# Altium schematic sheet nanoDLA.SchDoc
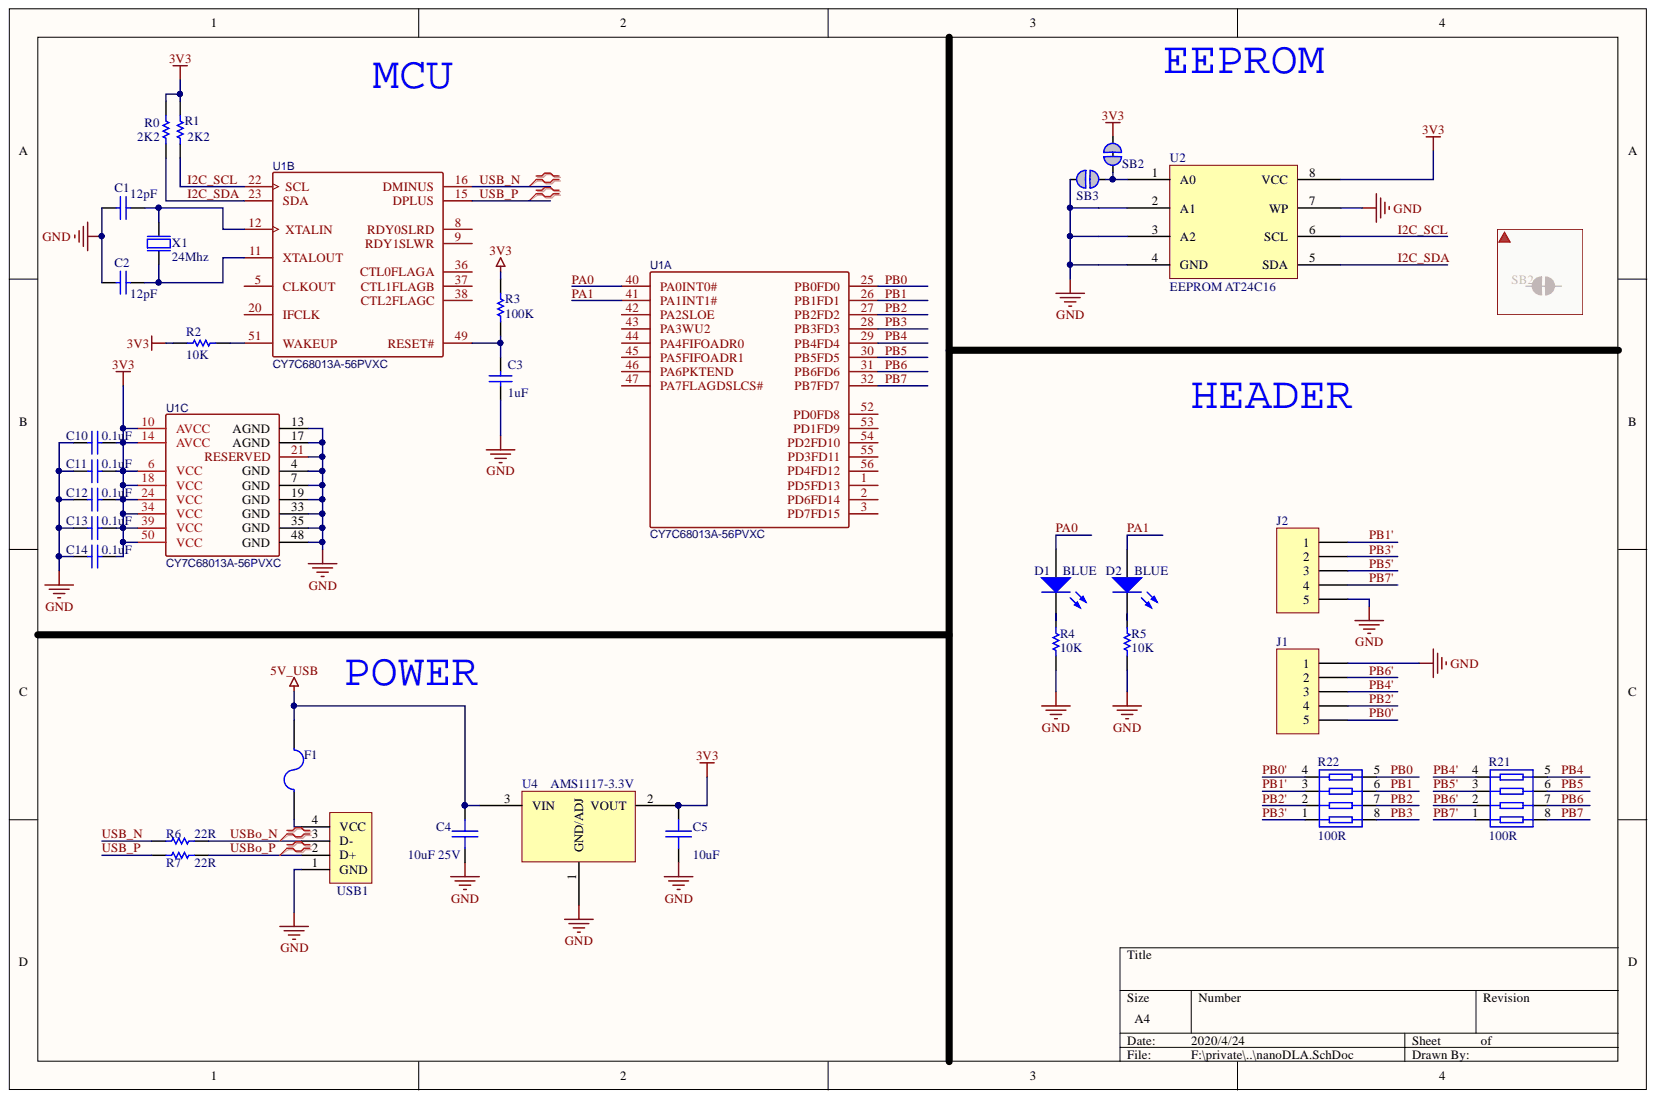
[diagram p0: nanoDLA.SchDoc]

## Parts
C1  value 12pF  [0603_C]  (hidden comment: C0603)
C2  value 12pF  [0603_C]  (hidden comment: C0603)
C3  value 1uF  [0603_C]  (hidden comment: C0603)
C4  10uF 25V  [0603_C]
C5  10uF  [0603_C]
C10  value 0.1uF  [0603_C]  (hidden comment: C0603)
C11  value 0.1uF  [0603_C]  (hidden comment: C0603)
C12  value 0.1uF  [0603_C]  (hidden comment: C0603)
C13  value 0.1uF  [0603_C]  (hidden comment: C0603)
C14  value 0.1uF  [0603_C]  (hidden comment: C0603)
D1  BLUE  [0603_LED]
D2  BLUE  [0603_LED]
F1  Fuse 2  [FU 0805]  (value not drawn)
J1  Header 5  [HEADER_SMT_5]  (value not drawn)
J2  Header 5  [HEADER_SMT_5]  (value not drawn)
R0  value 2K2  [0603_R]  (hidden comment: R0603)
R1  value 2K2  [0603_R]  (hidden comment: R0603)
R2  value 10K  [0603_R]  (hidden comment: R0603)
R3  value 100K  [0603_R]  (hidden comment: R0603)
R4  value 10K  [0603_R]  (hidden comment: R0603)
R5  value 10K  [0603_R]  (hidden comment: R0603)
R6  value 22R  [0603_R]  (hidden comment: R0603)
R7  value 22R  [0603_R]  (hidden comment: R0603)
R21  100R  [RCA-8P4R-0603]
R22  100R  [RCA-8P4R-0603]
SB2  Solderbridge  [Solderbridge_Shorted]  (value not drawn)
SB3  Solderbridge  [Solderbridge]  (value not drawn)  (no BOM)
U1  CY7C68013A-56PVXC  [SSOP-56_L18.5-W7.6-P0.63-LS10.7-BR]  (parts A,B,C)
U2  EEPROM AT24C16  [SOP8_M]
U4  AMS1117-3.3V  [SOT-89]
USB1  USB  [USB_A/P_B]
X1  24Mhz  [BT-XTAL_3225]

## Nets
3V3: C5.1 C10.1 C11.1 C12.1 C13.1 C14.1 R0.2 R1.2 R2.2 R3.1 SB2.1 U1.6(VCC) U1.10(AVCC) U1.14(AVCC) U1.18(VCC) U1.24(VCC) U1.34(VCC) U1.39(VCC) U1.50(VCC) U2.8(VCC) U4.2
5V_USB: C4.1 F1.2 U4.3
GND: C1.2 C2.2 C3.1 C4.2 C5.2 C10.2 C11.2 C12.2 C13.2 C14.2 J1.1 J2.5 R4.1 R5.1 SB3.1 U1.4(GND) U1.7(GND) U1.13(AGND) U1.17(AGND) U1.19(GND) U1.21(RESERVED) U1.33(GND) U1.35(GND) U1.48(GND) U2.2(A1) U2.3(A2) U2.4(GND) U2.7(WP) U4.1 USB1.1(GND) X1.2 X1.4
I2C_SCL: R1.1 U1.22(SCL) U2.6(SCL)
I2C_SDA: R0.1 U1.23(SDA) U2.5(SDA)
PA0: D1.1 U1.40(PA0INT0#)
PA1: D2.1 U1.41(PA1INT1#)
PB0: R22.5 U1.25(PB0FD0)
PB0': J1.5 R22.4
PB1: R22.6 U1.26(PB1FD1)
PB1': J2.1 R22.3
PB2: R22.7 U1.27(PB2FD2)
PB2': J1.4 R22.2
PB3: R22.8 U1.28(PB3FD3)
PB3': J2.2 R22.1
PB4: R21.5 U1.29(PB4FD4)
PB4': J1.3 R21.4
PB5: R21.6 U1.30(PB5FD5)
PB5': J2.3 R21.3
PB6: R21.7 U1.31(PB6FD6)
PB6': J1.2 R21.2
PB7: R21.8 U1.32(PB7FD7)
PB7': J2.4 R21.1
USB_N: R6.1 U1.16(DMINUS)
USB_P: R7.1 U1.15(DPLUS)
USBo_N: R6.2 USB1.3(D-)
USBo_P: R7.2 USB1.2(D+)
NetC1_1: C1.1 U1.12(XTALIN) X1.1
NetC2_1: C2.1 U1.11(XTALOUT) X1.3
NetC3_2: C3.2 R3.2 U1.49(RESET#)
NetD1_2: D1.2 R4.2
NetD2_2: D2.2 R5.2
NetF1_1: F1.1 USB1.4(VCC)
NetR2_1: R2.1 U1.51(WAKEUP)
NetSB2_2: SB2.2 SB3.2 U2.1(A0)

Not connected: U1 1(PD5FD13) 2(PD6FD14) 3(PD7FD15) 5(CLKOUT) 8(RDY0SLRD) 9(RDY1SLWR) 20(IFCLK) 36(CTL0FLAGA) 37(CTL1FLAGB) 38(CTL2FLAGC) 42(PA2SLOE) 43(PA3WU2) 44(PA4FIFOADR0) 45(PA5FIFOADR1) 46(PA6PKTEND) 47(PA7FLAGDSLCS#) 52(PD0FD8) 53(PD1FD9) 54(PD2FD10) 55(PD3FD11) 56(PD4FD12)

## Library data of the parts
C1-C3 C10-C14 = C0603: Capacitor; Component Kind: Standard; LatestRevisionDate: 17-Jul-2002; LatestRevisionNote: Re-released for DXP Platform.; Library Name: MYLIB.IntLib; PackageDescription: Radial Cap, Thru-Hole; 2 Leads; 0.3 in Pin Spacing; PackageReference: RAD-0.3; Published: 8-Jun-2000; Signal Integrity: Cap; Simulation: CAP; Publisher: Altium Limited
C4 = SMT_C_0805: Surface mount capacitor 0805; Component Kind: Standard; Library Name: Vowstar_Basic_Device.IntLib
C5 = SMT_C_0402: Surface mount capacitor 0402; Component Kind: Standard; Library Name: Vowstar_Basic_Device.IntLib
D1 D2 = D0603: Typical RED, GREEN, YELLOW, AMBER GaAs LED; Component Kind: Standard; LatestRevisionDate: 17-Jul-2002; LatestRevisionNote: Re-released for DXP Platform.; Library Name: MYLIB.IntLib; PackageDescription: SM LED; 2 Flat Leads; PackageReference: 3.2X1.6X1.1; PackageVersion: Feb-2002; Published: 8-Jun-2000; Simulation: LED2; Publisher: Altium Limited
F1 = Fuse 2: Fuse; Component Kind: Standard; LatestRevisionDate: 17-Jul-2002; LatestRevisionNote: Re-released for DXP Platform.; Library Name: Miscellaneous Devices.IntLib; PackageDescription: Thru-Hole; 2 Leads; PackageReference: PIN-W2/E2.8; Published: 8-Jun-2000; Simulation: FUSE; Publisher: Altium Limited
J1 J2 = Header_5: Header, 4-Pin; Component Kind: Standard; LatestRevisionDate: 17-Jul-2002; LatestRevisionNote: Re-released for DXP Platform.; Signal Integrity: Connector; Library Name: nanoDAP.SCHLIB; Publisher: Altium Limited
R0-R7 = R0603: Resistor; Component Kind: Standard; LatestRevisionDate: 17-Jul-2002; LatestRevisionNote: Re-released for DXP Platform.; Library Name: MYLIB.IntLib; PackageDescription: Axial Device, Thru-Hole; 2 Leads; 0.3 in Pin Spacing; PackageReference: AXIAL-0.3; Published: 8-Jun-2000; Signal Integrity: Res; Simulation: RESISTOR; Publisher: Altium Limited
R21 R22 = 排阻8PIN: Component Kind: Standard; Library Name: nanoDLA.SCHLIB
SB2 = Solderbridge Shorted: Shorted Solderbridge; Artikkelnr Noca: N.A.; Database Table Name: Connectors$; Artikkelnr Simpro: N.A.; Component Kind: Net Tie; Footprint Doc: Solderbridge_Shorted; LatestRevisionDate: 2012-08-07; Library Name: Nordic Passive DB.DbLib; Part Number: Solderbridge Shorted; Pin Count: 2; Published: 2012-08-07; Value: Solderbridge; Publisher: Nordic Semiconductor
SB3 = (no symbol name): Artikkelnr Noca: N.A.; Artikkelnr Simpro: N.A.; Description: Solderbridge; Footprint Doc: Solderbridge; LatestRevisionDate: 2009-12-14; Library Name: NODEMCU_ESP12.SCHLIB; Part Number: Solderbridge; Pin Count: 2; Published: 2009-12-14; Value: Solderbridge; Publisher: Nordic Semiconductor
U1 = CY7C68013A-56PVXC: BOM_Manufacturer: CYPRESS; subpart_no: 1; tags: PSOC1-M8C; BOM_Manufacturer Part: CY7C68013A-56PVXC; BOM_Supplier: LCSC; BOM_Supplier Part: C26717; Component Kind: Standard; image: http://image.lceda.cn/szlcsc/C26717.jpg; spicePre: U; spiceSymbolName: CY7C68013A-56PVXC; Library Name: nanoDLA.SCHLIB
U2 = EEPROM: Component Kind: Standard; Library Name: programmer.SCHLIB
U4 = NCP1117: NCP1117, NCV1117. 1.0 A Low-Dropout Positive  Fixed and Adjustable  Voltage Regulators; Component Kind: Standard; Library Name: Vowstar_IC_General.IntLib
USB1 = USB_G: Component Kind: Standard; Library Name: nanoDAP.SCHLIB
X1 = XTAL SMD 12MHz (3225): XTAL SMD 3225, 24MHz, 18pF, ±30ppm; 2nd source part number: 184-2056; Manufacturer: TXC Corporation; Manufacturer Part Number: 7M-12.000MAAJ-T; Artikkelnr Noca: 22019166; Artikkelnr Simpro: N.A.; Database Table Name: Crystal_Osc$; Note: XTAL SMD 12.000MHz; 2nd source Supplier: Farnell; Component Kind: Standard; Datasheet: http://www.txccrystal.com/images/pdf/7m.pdf; Footprint Doc: BT-XTAL_3225; LatestRevisionDate: 2010-08-31; Library Name: Nordic Discrete DB.DbLib; Part Number: XTAL SMD 12MHz (3225); Pin Count: 4; Published: 2010-08-31; Supplier: Digi-Key; Supplier part number: 887-1121-1-ND; Value: 24Mhz; Publisher: Nordic Semiconductor
